# Altium schematic sheet StepGenBufRev2b.SchDoc
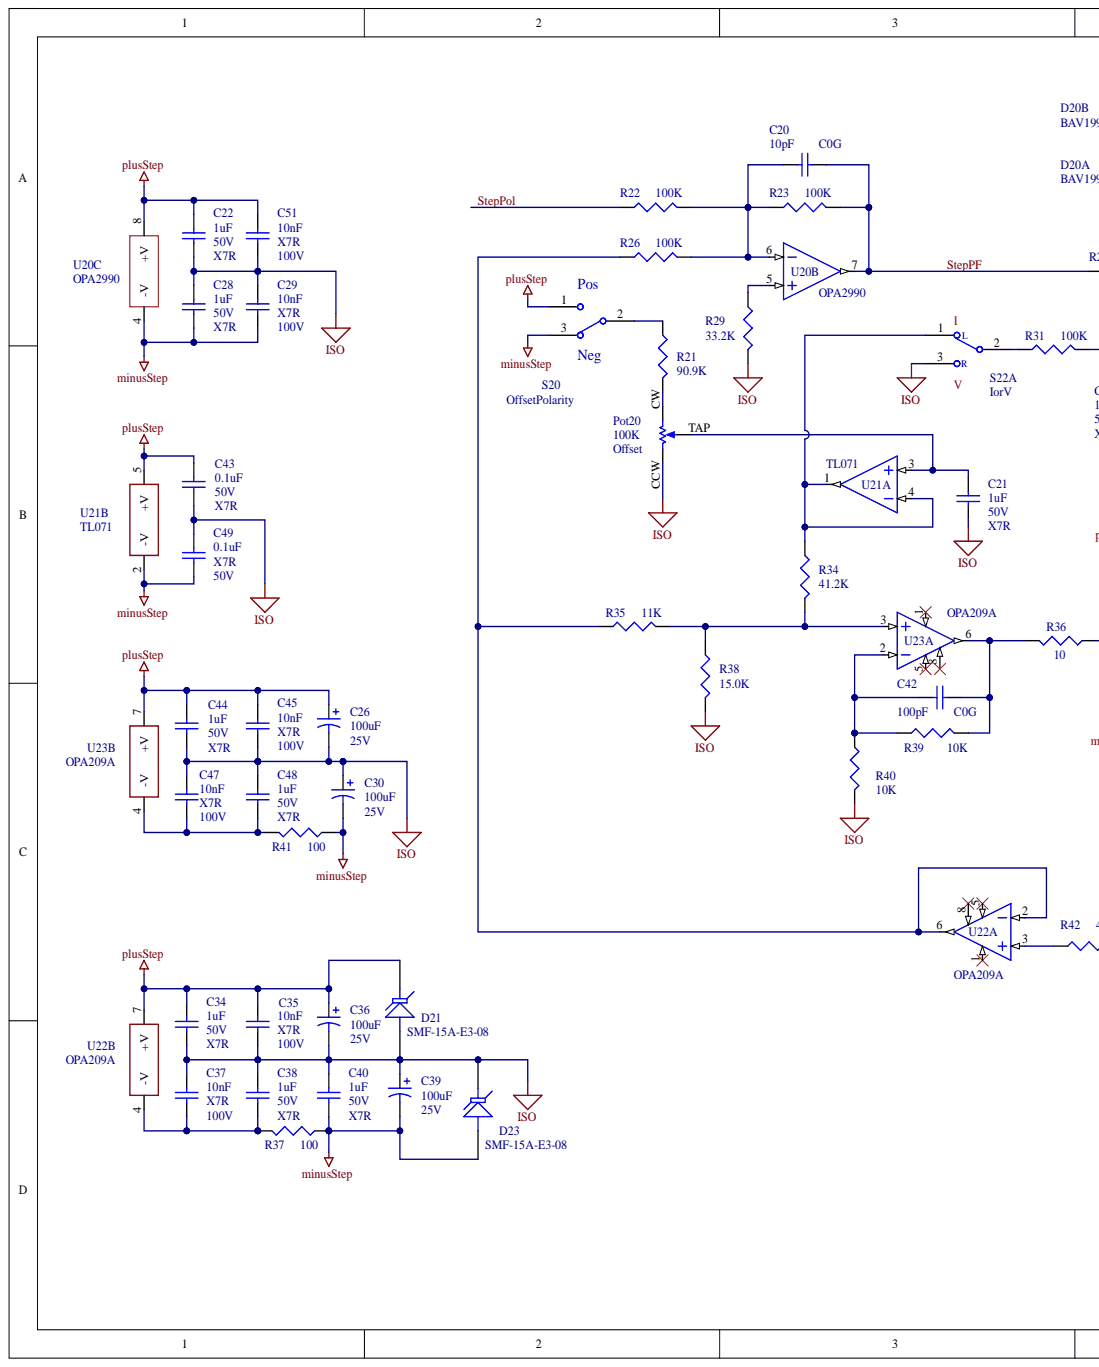
[diagram p0: StepGenBufRev2b.SchDoc, left]
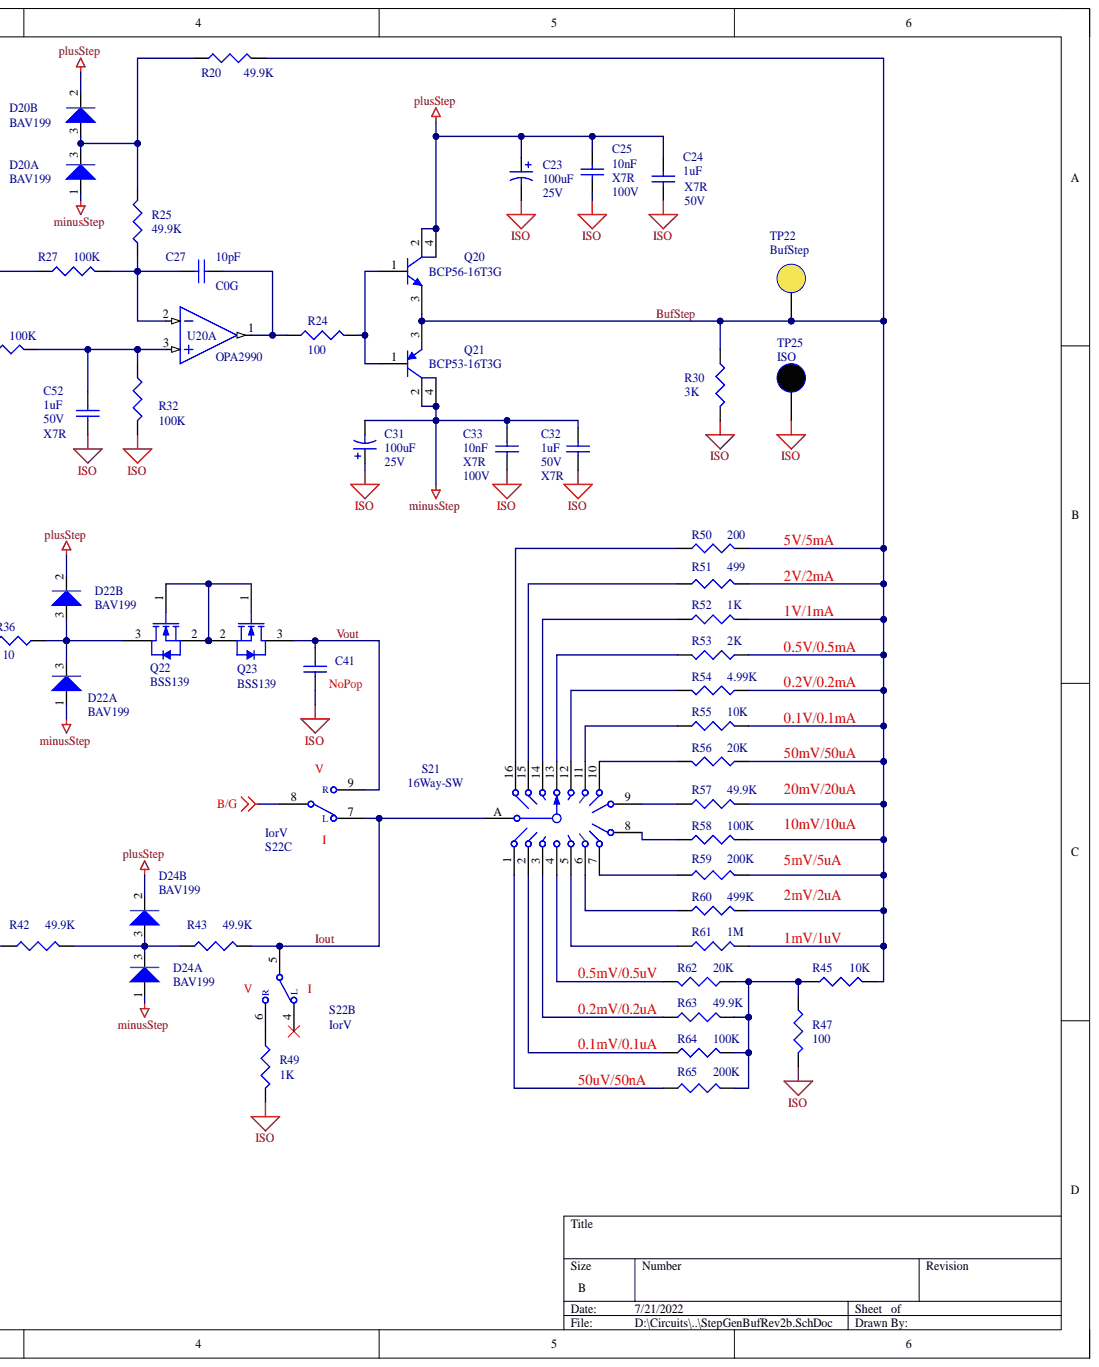
[diagram p1: StepGenBufRev2b.SchDoc, right]

## Parts
C20  value 10pF  Capacitance: 10pF  Dielectric: C0G  [CAPC2012M]  (hidden comment: 2pF)
C21  1uF  Dielectric: X7R  Voltage: 50V  [CAPC2012M]
C22  1uF  Dielectric: X7R  Voltage: 50V  [CAPC2012M]
C23  100uF  Voltage: 25V  [CAPPR2-5x11]
C24  1uF  Dielectric: X7R  Voltage: 50V  [CAPC2012M]
C25  10nF  Dielectric: X7R  Voltage: 100V  [CAPC2012M]
C26  100uF  Voltage: 25V  [CAPPR2-5x11]
C27  10pF  Dielectric: C0G  [CAPC2012M]
C28  1uF  Dielectric: X7R  Voltage: 50V  [CAPC2012M]
C29  10nF  Dielectric: X7R  Voltage: 100V  [CAPC2012M]
C30  100uF  Voltage: 25V  [CAPPR2-5x11]
C31  100uF  Voltage: 25V  [CAPPR2-5x11]
C32  1uF  Dielectric: X7R  Voltage: 50V  [CAPC2012M]
C33  10nF  Dielectric: X7R  Voltage: 100V  [CAPC2012M]
C34  1uF  Dielectric: X7R  Voltage: 50V  [CAPC2012M]
C35  10nF  Dielectric: X7R  Voltage: 100V  [CAPC2012M]
C36  100uF  Voltage: 25V  [CAPPR2-5x11]
C37  10nF  Dielectric: X7R  Voltage: 100V  [CAPC2012M]
C38  1uF  Dielectric: X7R  Voltage: 50V  [CAPC2012M]
C39  100uF  Voltage: 25V  [CAPPR2-5x11]
C40  1uF  Dielectric: X7R  Voltage: 50V  [CAPC2012M]
C41  NoPop  [CAPC2012M]
C42  100pF  Dielectric: C0G  [CAPC2012M]
C43  0.1uF  Dielectric: X7R  Voltage: 50V  [CAPC2012M]
C44  1uF  Dielectric: X7R  Voltage: 50V  [CAPC2012M]
C45  10nF  Dielectric: X7R  Voltage: 100V  [CAPC2012M]
C47  10nF  Dielectric: X7R  Voltage: 100V  [CAPC2012M]
C48  1uF  Dielectric: X7R  Voltage: 50V  [CAPC2012M]
C49  0.1uF  Dielectric: X7R  Voltage: 50V  [CAPC2012M]
C51  10nF  Dielectric: X7R  Voltage: 100V  [CAPC2012M]
C52  1uF  Dielectric: X7R  Voltage: 50V  [CAPC2012M]
D20  BAV199  [SOT23-3M]  (parts A,B)
D21  SMF-15A  value SMF-15A-E3-08  [SMF]
D22  BAV199  [SOT23-3M]  (parts A,B)
D23  SMF-15A  value SMF-15A-E3-08  [SMF]
D24  BAV199  [SOT23-3M]  (parts A,B)
Pot20  Offset  value 100K  [Off-PCB-CCW]
Q20  Manufacturer Part Number: BCP56-16T3G  [SOT223-4M]  (hidden comment: NPN-2C)
Q21  Manufacturer Part Number: BCP53-16T3G  [SOT223-4M]  (hidden comment: PNP-2C)
Q22  BSS139  [SOT23-3M]
Q23  BSS139  [SOT23-3M]
R20  49.9K  [RESC2012M]
R21  value 90.9K  [RESC2012M]  (hidden comment: 100K)
R22  100K  [RESC2012M]
R23  100K  [RESC2012M]
R24  100  [RESC2012M]
R25  49.9K  [RESC2012M]
R26  100K  [RESC2012M]
R27  100K  [RESC2012M]
R29  value 33.2K  [RESC2012M]  (hidden comment: 3.32KXXK)
R30  3K  [RESC2012M]
R31  100K  [RESC2012M]
R32  100K  [RESC2012M]
R34  value 41.2K  [RESC2012M]  (hidden comment: 20K)
R35  value 11K  [RESC2012M]  (hidden comment: 10K)
R36  value 10  [RESC2012M]  (hidden comment: 10R0)
R37  100  [RESC2012M]
R38  value 15.0K  [RESC2012M]  (hidden comment: 20K)
R39  value 10K  [RESC2012M]  (hidden comment: 100K)
R40  value 10K  [RESC2012M]  (hidden comment: 100K)
R41  100  [RESC2012M]
R42  49.9K  [RESC2012M]
R43  49.9K  [RESC2012M]
R45  10K  [RESC2012M]
R47  100  [RESC2012M]
R49  1K  [RESC3216M]
R50  value 200  [RESC6432M]  (hidden comment: 301)
R51  499  [RESC6432M]
R52  1K  [RESC6432M]
R53  2K  [RESC2012M]
R54  4.99K  [RESC2012M]
R55  10K  [RESC2012M]
R56  20K  [RESC2012M]
R57  49.9K  [RESC2012M]
R58  100K  [RESC2012M]
R59  200K  [RESC2012M]
R60  499K  [RESC2012M]
R61  1M  [RESC2012M]
R62  20K  [RESC2012M]
R63  49.9K  [RESC2012M]
R64  100K  [RESC2012M]
R65  200K  [RESC2012M]
S20  OffsetPolarity  [EG2377]
S21  16Way-SW  [GRAYHILL-51-16-LgPin]
S22  IorV  [EG2425]  (parts A,B,C)
TP22  BufStep  [TP-.038]
TP25  ISO  [TP-.038]
U20  OPA2990  [SOIC127P600X168_HS-8N]  (parts A,B,C)
U21  TL071  [SOT95P300X130-5M]  (parts A,B)
U22  OPA209A  [SOIC127P600X168_HS-8N]  (parts A,B)
U23  OPA209A  [SOIC127P600X168_HS-8N]  (parts A,B)

## Nets
B/G: S22.8
ISO: C21.2 C22.1 C23.2 C24.2 C25.2 C26.2 C28.2 C29.2 C30.1 C31.1 C32.2 C33.2 C34.2 C35.2 C36.2 C37.2 C38.2 C39.1 C40.2 C41.2 C43.2 C44.2 C45.2 C47.2 C48.2 C49.2 C51.1 C52.2 D21.1 D23.2 Pot20.CCW R29.2 R30.2 R32.2 R38.2 R40.2 R47.2 R49.2 S22.3(R) TP25.1
minusStep: C28.1 C29.1 C30.2 C31.2 C32.1 C33.1 C39.2 C40.1 C49.1 D20.1 D22.1 D23.1 D24.1 Q21.2 Q21.4 R37.2 R41.2 S20.3 U20.4(-V) U21.2(-V)
plusStep: C22.2 C23.1 C24.1 C25.1 C26.1 C34.1 C35.1 C36.1 C43.1 C44.1 C45.1 C51.2 D20.2 D21.2 D22.2 D24.2 Q20.2 Q20.4 S20.1 U20.8(+V) U21.5(+V) U22.7(+V) U23.7(+V)
BufStep: Q20.3 Q21.3 R20.2 R30.1 R45.2 R50.2 R51.2 R52.2 R53.2 R54.1 R55.2 R56.2 R57.2 R58.2 R59.2 R60.2 R61.2 TP22.1
Iout: R43.2 S21.A S22.5 S22.7(L)
StepPF: C20.2 R23.2 R27.1 U20.7
StepPol: R22.1
Vout: C41.1 Q23.3 S22.9(R)
NetC20_1: C20.1 R22.2 R23.1 R26.2 U20.6
NetC21_1: C21.1 Pot20.TAP U21.3
NetC27_1: C27.1 R25.1 R27.2 U20.2
NetC27_2: C27.2 R24.1 U20.1
NetC37_1: C37.1 C38.1 R37.1 U22.4(-V)
NetC42_1: C42.1 R39.1 R40.1 U23.2
NetC42_2: C42.2 R36.2 R39.2 U23.6
NetC47_1: C47.1 C48.1 R41.1 U23.4(-V)
NetC52_1: C52.1 R31.2 R32.1 U20.3
NetD20_3: D20.3 R20.1 R25.2
NetD22_3: D22.3 Q22.3 R36.1
NetD24_3: D24.3 R42.2 R43.1
NetPot20_CW: Pot20.CW R21.2
NetQ20_1: Q20.1 Q21.1 R24.2
NetQ22_1: Q22.1 Q22.2 Q23.1 Q23.2
NetR21_1: R21.1 S20.2
NetR26_1: R26.1 R35.1 U22.2 U22.6
NetR29_1: R29.1 U20.5
NetR31_1: R31.1 S22.2
NetR34_1: R34.1 S22.1(L) U21.1 U21.4
NetR34_2: R34.2 R35.2 R38.1 U23.3
NetR42_1: R42.1 U22.3
NetR45_1: R45.1 R47.1 R62.2 R63.2 R64.2 R65.2
NetR49_1: R49.1 S22.6(R)
NetR50_1: R50.1 S21.16
NetR51_1: R51.1 S21.15
NetR52_1: R52.1 S21.14
NetR53_1: R53.1 S21.13
NetR54_2: R54.2 S21.12
NetR55_1: R55.1 S21.11
NetR56_1: R56.1 S21.10
NetR57_1: R57.1 S21.9
NetR58_1: R58.1 S21.8
NetR59_1: R59.1 S21.7
NetR60_1: R60.1 S21.6
NetR61_1: R61.1 S21.5
NetR62_1: R62.1 S21.4
NetR63_1: R63.1 S21.3
NetR64_1: R64.1 S21.2
NetR65_1: R65.1 S21.1

Not connected: S22 4(L); U22 1 5 8; U23 1 5 8
